# Altium schematic sheet Voltage_Regulators.SchDoc
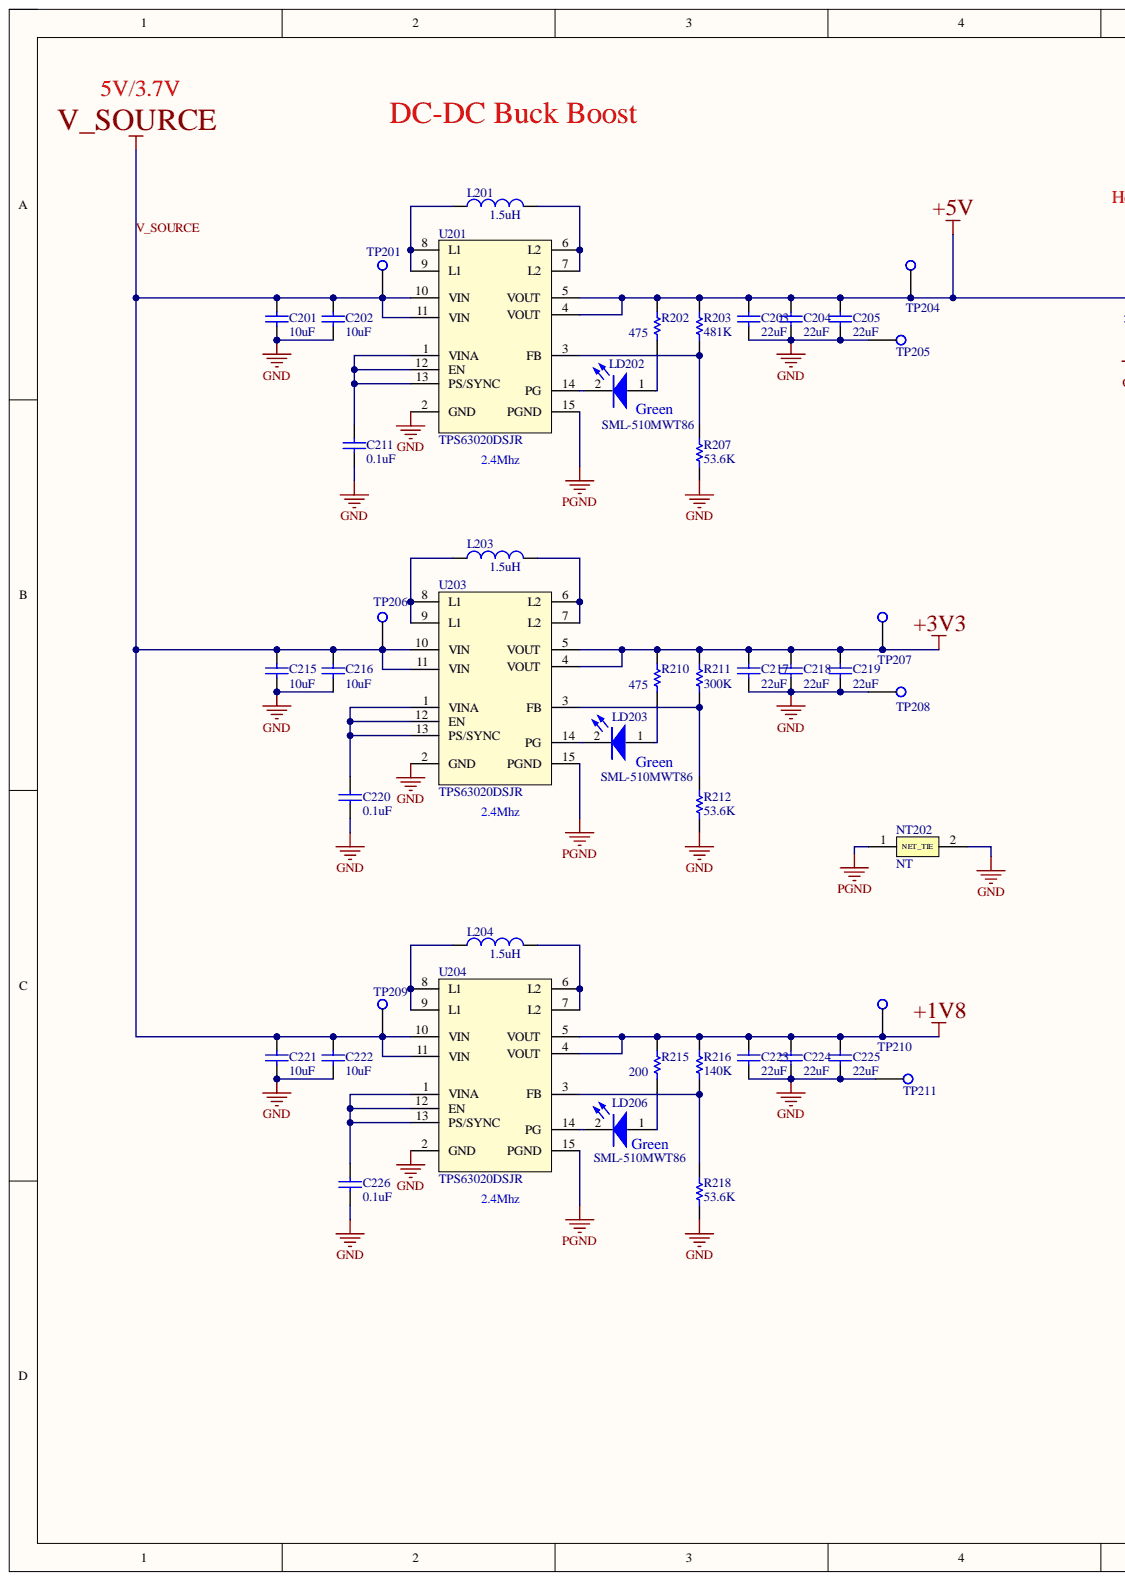
[diagram p0: Voltage_Regulators.SchDoc, left]
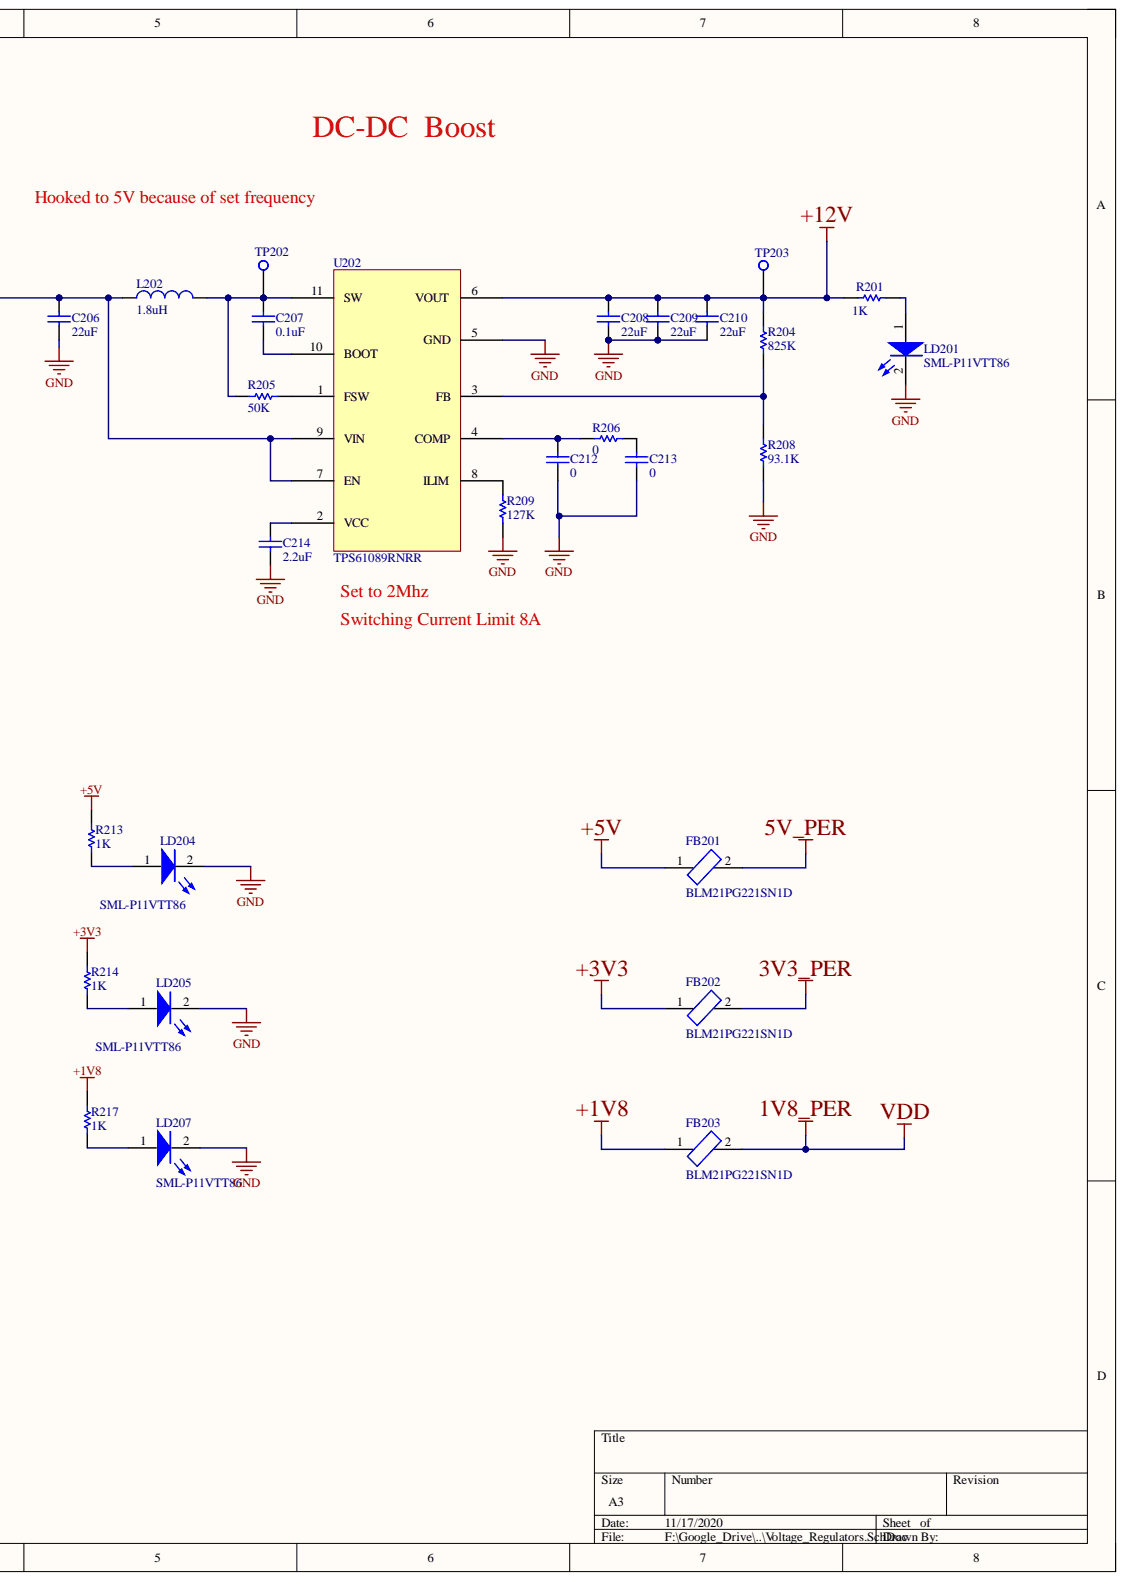
[diagram p1: Voltage_Regulators.SchDoc, right]

## Parts
C201  10uF  [CAP 0603]
C202  10uF  [CAP 0603]
C203  22uF  [Cap 0805]
C204  22uF  [Cap 0805]
C205  22uF  [Cap 0805]
C206  22uF  [Cap 0805]
C207  0.1uF  [Cap 0402]
C208  22uF  [CAP 0603]
C209  22uF  [CAP 0603]
C210  22uF  [CAP 0603]
C211  0.1uF  [Cap 0402]
C212  0  [Cap 0402]
C213  0  [Cap 0402]
C214  2.2uF  [CAP 0603]
C215  10uF  [CAP 0603]
C216  10uF  [CAP 0603]
C217  22uF  [Cap 0805]
C218  22uF  [Cap 0805]
C219  22uF  [Cap 0805]
C220  0.1uF  [Cap 0402]
C221  10uF  [CAP 0603]
C222  10uF  [CAP 0603]
C223  22uF  [Cap 0805]
C224  22uF  [Cap 0805]
C225  22uF  [Cap 0805]
C226  0.1uF  [Cap 0402]
FB201  BLM21PG221SN1D  [BLM21PG221SN1D]
FB202  BLM21PG221SN1D  [BLM21PG221SN1D]
FB203  BLM21PG221SN1D  [BLM21PG221SN1D]
L201  1.5uH  [IHLP-2525CZ-01]
L202  1.8uH  [7447798180]
L203  1.5uH  [IHLP-2525CZ-01]
L204  1.5uH  [IHLP-2525CZ-01]
LD201  SML-P11VTT86  [LED 0402]
LD202  SML-510MWT86  [LED 0603]
LD203  SML-510MWT86  [LED 0603]
LD204  SML-P11VTT86  [LED 0402]
LD205  SML-P11VTT86  [LED 0402]
LD206  SML-510MWT86  [LED 0603]
LD207  SML-P11VTT86  [LED 0402]
NT202  NT  [NetTie]
R201  1K  [Res 0402]
R202  475  [Res 0402]
R203  481K  [Res 0402]
R204  825K  [RES 0603]
R205  50K  [RES 0603]
R206  0  [RES 0603]
R207  53.6K  [Res 0402]
R208  93.1K  [RES 0603]
R209  127K  [RES 0603]
R210  475  [Res 0402]
R211  300K  [Res 0402]
R212  53.6K  [Res 0402]
R213  1K  [Res 0402]
R214  1K  [Res 0402]
R215  200  [Res 0402]
R216  140K  [Res 0402]
R217  1K  [Res 0402]
R218  53.6K  [Res 0402]
TP201  Test Point  [TP]  (value not drawn)
TP202  Test Point  [TP]  (value not drawn)
TP203  Test Point  [TP]  (value not drawn)
TP204  Test Point  [TP]  (value not drawn)
TP205  Test Point  [TP]  (value not drawn)
TP206  Test Point  [TP]  (value not drawn)
TP207  Test Point  [TP]  (value not drawn)
TP208  Test Point  [TP]  (value not drawn)
TP209  Test Point  [TP]  (value not drawn)
TP210  Test Point  [TP]  (value not drawn)
TP211  Test Point  [TP]  (value not drawn)
U201  TPS63020DSJR  [TPS63020DSJ]
U202  TPS61089RNRR  [TPS61089RNRR]
U203  TPS63020DSJR  [TPS63020DSJ]
U204  TPS63020DSJR  [TPS63020DSJ]

## Nets
1V8_PER: C223.2 C224.2 C225.2 FB203.1 FB203.2 R215.1 R216.2 R217.2 TP210.1 U204.4(VOUT) U204.5(VOUT)
3V3_PER: C217.2 C218.2 C219.2 FB202.1 FB202.2 R210.1 R211.2 R214.2 TP207.1 U203.4(VOUT) U203.5(VOUT)
5V_PER: C203.2 C204.2 C205.2 C206.2 FB201.1 FB201.2 L202.1 R202.1 R203.2 R213.2 TP204.1 U201.4(VOUT) U201.5(VOUT) U202.7(EN) U202.9(VIN)
+12V: C208.2 C209.2 C210.2 R201.2 R204.2 TP203.1 U202.6(VOUT)
GND: C201.1 C202.1 C203.1 C204.1 C205.1 C206.1 C208.1 C209.1 C210.1 C211.1 C212.1 C213.1 C214.1 C215.1 C216.1 C217.1 C218.1 C219.1 C220.1 C221.1 C222.1 C223.1 C224.1 C225.1 C226.1 LD201.2 LD204.2 LD205.2 LD207.2 NT202.2 R207.1 R208.1 R209.2 R212.1 R218.1 TP205.1 TP208.1 TP211.1 U201.2(GND) U202.5(GND) U203.2(GND) U204.2(GND)
PGND: NT202.1 U201.15(PGND) U203.15(PGND) U204.15(PGND)
V_SOURCE: C201.2 C202.2 C215.2 C216.2 C221.2 C222.2 TP201.1 TP206.1 TP209.1 U201.10(VIN) U201.11(VIN) U203.10(VIN) U203.11(VIN) U204.10(VIN) U204.11(VIN)
NetC207_1: C207.1 U202.10(BOOT)
NetC207_2: C207.2 L202.2 R205.1 TP202.1 U202.11(SW)
NetC211_2: C211.2 U201.1(VINA) U201.12(EN) U201.13(PS/SYNC)
NetC212_2: C212.2 R206.2 U202.4(COMP)
NetC213_2: C213.2 R206.1
NetC214_2: C214.2 U202.2(VCC)
NetC220_2: C220.2 U203.1(VINA) U203.12(EN) U203.13(PS/SYNC)
NetC226_2: C226.2 U204.1(VINA) U204.12(EN) U204.13(PS/SYNC)
NetL201_1: L201.1 U201.8(L1) U201.9(L1)
NetL201_2: L201.2 U201.6(L2) U201.7(L2)
NetL203_1: L203.1 U203.8(L1) U203.9(L1)
NetL203_2: L203.2 U203.6(L2) U203.7(L2)
NetL204_1: L204.1 U204.8(L1) U204.9(L1)
NetL204_2: L204.2 U204.6(L2) U204.7(L2)
NetLD201_1: LD201.1 R201.1
NetLD202_1: LD202.1 R202.2
NetLD202_2: LD202.2 U201.14(PG)
NetLD203_1: LD203.1 R210.2
NetLD203_2: LD203.2 U203.14(PG)
NetLD204_1: LD204.1 R213.1
NetLD205_1: LD205.1 R214.1
NetLD206_1: LD206.1 R215.2
NetLD206_2: LD206.2 U204.14(PG)
NetLD207_1: LD207.1 R217.1
NetR203_1: R203.1 R207.2 U201.3(FB)
NetR204_1: R204.1 R208.2 U202.3(FB)
NetR205_2: R205.2 U202.1(FSW)
NetR209_1: R209.1 U202.8(ILIM)
NetR211_1: R211.1 R212.2 U203.3(FB)
NetR216_1: R216.1 R218.2 U204.3(FB)

## Library data of the parts
C201 C202 C215 C216 C221 C222 = Cap: GRM188R60J106ME47D, 0603; Component Kind: Standard; LatestRevisionDate: 17-Jul-2002; LatestRevisionNote: Re-released for DXP Platform.; Library Name: Miscellaneous Devices.IntLib; PackageDescription: Radial Cap, Thru-Hole; 2 Leads; 0.3 in Pin Spacing; PackageReference: RAD-0.3; Published: 8-Jun-2000; Signal Integrity: Cap; Simulation: CAP; Publisher: Altium Limited
C203-C206 C217-C219 C223-C225 = Cap: GRM21BR60J226ME39K , 0805; Component Kind: Standard; LatestRevisionDate: 17-Jul-2002; LatestRevisionNote: Re-released for DXP Platform.; Library Name: Miscellaneous Devices.IntLib; PackageDescription: Radial Cap, Thru-Hole; 2 Leads; 0.3 in Pin Spacing; PackageReference: RAD-0.3; Published: 8-Jun-2000; Signal Integrity: Cap; Simulation: CAP; Publisher: Altium Limited
C207 C211 C220 C226 = Cap: GRM155R71C104KA88, 0402; Component Kind: Standard; LatestRevisionDate: 17-Jul-2002; LatestRevisionNote: Re-released for DXP Platform.; Library Name: Miscellaneous Devices.IntLib; PackageDescription: Radial Cap, Thru-Hole; 2 Leads; 0.3 in Pin Spacing; PackageReference: RAD-0.3; Published: 8-Jun-2000; Signal Integrity: Cap; Simulation: CAP; Publisher: Altium Limited
C208-C210 = Cap: GRM188R71C224KA01D, 0603; Component Kind: Standard; LatestRevisionDate: 17-Jul-2002; LatestRevisionNote: Re-released for DXP Platform.; Library Name: Miscellaneous Devices.IntLib; PackageDescription: Radial Cap, Thru-Hole; 2 Leads; 0.3 in Pin Spacing; PackageReference: RAD-0.3; Published: 8-Jun-2000; Signal Integrity: Cap; Simulation: CAP; Publisher: Altium Limited
C212 C213 = Cap: Component Kind: Standard; LatestRevisionDate: 17-Jul-2002; LatestRevisionNote: Re-released for DXP Platform.; Library Name: Miscellaneous Devices.IntLib; PackageDescription: Radial Cap, Thru-Hole; 2 Leads; 0.3 in Pin Spacing; PackageReference: RAD-0.3; Published: 8-Jun-2000; Signal Integrity: Cap; Simulation: CAP; Publisher: Altium Limited
C214 = Cap: GRM188R71A225KE15. 0603; Component Kind: Standard; LatestRevisionDate: 17-Jul-2002; LatestRevisionNote: Re-released for DXP Platform.; Library Name: Miscellaneous Devices.IntLib; PackageDescription: Radial Cap, Thru-Hole; 2 Leads; 0.3 in Pin Spacing; PackageReference: RAD-0.3; Published: 8-Jun-2000; Signal Integrity: Cap; Simulation: CAP; Publisher: Altium Limited
FB201-FB203 = BLM21PG221SN1D: Ferrite_Bead; Component Kind: Standard; Library Name: MA_Eva_Board.SchLib
L201 L203 L204 = Inductor: IHLP2525CZER1R5M01; Code_IEC: 1005; Code_JEITA: 0402; Component Kind: Standard; LatestRevisionDate: 29-May-2009; LatestRevisionNote: IPC-7351 Footprint Added.; Library Name: Miscellaneous Devices.IntLib; PackageDescription: Chip Inductor; PackageReference: 0402-A; Published: 8-Jun-2000; Signal Integrity: Inductor; Simulation: INDUCTOR; Publisher: Altium Limited
L202 = Inductor: CDMC8D28NP-1R8MC; Code_IEC: 1005; Code_JEITA: 0402; Component Kind: Standard; LatestRevisionDate: 29-May-2009; LatestRevisionNote: IPC-7351 Footprint Added.; Library Name: Miscellaneous Devices.IntLib; PackageDescription: Chip Inductor; PackageReference: 0402-A; Published: 8-Jun-2000; Signal Integrity: Inductor; Simulation: INDUCTOR; Publisher: Altium Limited
LD201 LD204 LD205 LD207 = LED_Green: LED RED 0402 SMD; Component Kind: Standard; Library Name: MA_Eva_Board.SchLib
LD202 LD203 LD206 = LED_Green: LED GREEN DIFFUSED 0603 SMD; Component Kind: Standard; Library Name: MA_Eva_Board.SchLib
NT202 = Nettie: Net tie; Component Kind: Net Tie; Library Name: MA_Eva_Board.SchLib
R201 R213 R214 R217 = Res1: ERA-2AEB102X, 0402; Component Kind: Standard; LatestRevisionDate: 17-Jul-2002; LatestRevisionNote: Re-released for DXP Platform.; Library Name: Miscellaneous Devices.IntLib; PackageDescription: Axial Device, Thru-Hole; 2 Leads; 0.3 in Pin Spacing; PackageReference: AXIAL-0.3; Published: 8-Jun-2000; Signal Integrity: Res; Simulation: RESISTOR; Publisher: Altium Limited
R202 R210 = Res1: ERA-2AEB4750X, 0402; Component Kind: Standard; LatestRevisionDate: 17-Jul-2002; LatestRevisionNote: Re-released for DXP Platform.; Library Name: Miscellaneous Devices.IntLib; PackageDescription: Axial Device, Thru-Hole; 2 Leads; 0.3 in Pin Spacing; PackageReference: AXIAL-0.3; Published: 8-Jun-2000; Signal Integrity: Res; Simulation: RESISTOR; Publisher: Altium Limited
R203 = Res1: RT0603BRD07481KL, 0603; Component Kind: Standard; LatestRevisionDate: 17-Jul-2002; LatestRevisionNote: Re-released for DXP Platform.; Library Name: Miscellaneous Devices.IntLib; PackageDescription: Axial Device, Thru-Hole; 2 Leads; 0.3 in Pin Spacing; PackageReference: AXIAL-0.3; Published: 8-Jun-2000; Signal Integrity: Res; Simulation: RESISTOR; Publisher: Altium Limited
R204 = Res1: ERJ-3EKF8253V, 0603; Component Kind: Standard; LatestRevisionDate: 17-Jul-2002; LatestRevisionNote: Re-released for DXP Platform.; Library Name: Miscellaneous Devices.IntLib; PackageDescription: Axial Device, Thru-Hole; 2 Leads; 0.3 in Pin Spacing; PackageReference: AXIAL-0.3; Published: 8-Jun-2000; Signal Integrity: Res; Simulation: RESISTOR; Publisher: Altium Limited
R205 = Res1: ERA-3AEB4992V, 0603; Component Kind: Standard; LatestRevisionDate: 17-Jul-2002; LatestRevisionNote: Re-released for DXP Platform.; Library Name: Miscellaneous Devices.IntLib; PackageDescription: Axial Device, Thru-Hole; 2 Leads; 0.3 in Pin Spacing; PackageReference: AXIAL-0.3; Published: 8-Jun-2000; Signal Integrity: Res; Simulation: RESISTOR; Publisher: Altium Limited
R206 = Res1: ERA-3AEB1073V, 0603; Component Kind: Standard; LatestRevisionDate: 17-Jul-2002; LatestRevisionNote: Re-released for DXP Platform.; Library Name: Miscellaneous Devices.IntLib; PackageDescription: Axial Device, Thru-Hole; 2 Leads; 0.3 in Pin Spacing; PackageReference: AXIAL-0.3; Published: 8-Jun-2000; Signal Integrity: Res; Simulation: RESISTOR; Publisher: Altium Limited
R207 R212 R218 = Res1: ERJ-2RKF5362X, 0402; Component Kind: Standard; LatestRevisionDate: 17-Jul-2002; LatestRevisionNote: Re-released for DXP Platform.; Library Name: Miscellaneous Devices.IntLib; PackageDescription: Axial Device, Thru-Hole; 2 Leads; 0.3 in Pin Spacing; PackageReference: AXIAL-0.3; Published: 8-Jun-2000; Signal Integrity: Res; Simulation: RESISTOR; Publisher: Altium Limited
R208 = Res1: ERA-3AEB9312V, 0603; Component Kind: Standard; LatestRevisionDate: 17-Jul-2002; LatestRevisionNote: Re-released for DXP Platform.; Library Name: Miscellaneous Devices.IntLib; PackageDescription: Axial Device, Thru-Hole; 2 Leads; 0.3 in Pin Spacing; PackageReference: AXIAL-0.3; Published: 8-Jun-2000; Signal Integrity: Res; Simulation: RESISTOR; Publisher: Altium Limited
R209 = Res1: ERJ-3EKF1273V, 0603; Component Kind: Standard; LatestRevisionDate: 17-Jul-2002; LatestRevisionNote: Re-released for DXP Platform.; Library Name: Miscellaneous Devices.IntLib; PackageDescription: Axial Device, Thru-Hole; 2 Leads; 0.3 in Pin Spacing; PackageReference: AXIAL-0.3; Published: 8-Jun-2000; Signal Integrity: Res; Simulation: RESISTOR; Publisher: Altium Limited
R211 = Res1: ERA-3AEB304V, 0603; Component Kind: Standard; LatestRevisionDate: 17-Jul-2002; LatestRevisionNote: Re-released for DXP Platform.; Library Name: Miscellaneous Devices.IntLib; PackageDescription: Axial Device, Thru-Hole; 2 Leads; 0.3 in Pin Spacing; PackageReference: AXIAL-0.3; Published: 8-Jun-2000; Signal Integrity: Res; Simulation: RESISTOR; Publisher: Altium Limited
R215 = Res1: ERA-2AEB201X, 0402; Component Kind: Standard; LatestRevisionDate: 17-Jul-2002; LatestRevisionNote: Re-released for DXP Platform.; Library Name: Miscellaneous Devices.IntLib; PackageDescription: Axial Device, Thru-Hole; 2 Leads; 0.3 in Pin Spacing; PackageReference: AXIAL-0.3; Published: 8-Jun-2000; Signal Integrity: Res; Simulation: RESISTOR; Publisher: Altium Limited
R216 = Res1: ERA-3AEB1403V, 0603; Component Kind: Standard; LatestRevisionDate: 17-Jul-2002; LatestRevisionNote: Re-released for DXP Platform.; Library Name: Miscellaneous Devices.IntLib; PackageDescription: Axial Device, Thru-Hole; 2 Leads; 0.3 in Pin Spacing; PackageReference: AXIAL-0.3; Published: 8-Jun-2000; Signal Integrity: Res; Simulation: RESISTOR; Publisher: Altium Limited
U201 U203 U204 = TPS63020DSJR: High Efficiency Single Inductor Buck-Boost Converter with 4A Switch; Component Kind: Standard; Library Name: MA_Eva_Board.SchLib
U202 = TPS61089RNRR: 12.6-V, 7-A Fully-Integrated Synchronous Boost Converters  in 2.0-mm x 2.5-mm; Component Kind: Standard; Library Name: MA_Eva_Board.SchLib
TP201-TP211 = Test Point: Component Kind: Standard; Description: Test Point; Library Name: MA_Eva_Board.SchLib
